# Altium schematic sheet 19_2_Coupled_PP0_Top.SchDoc(19_2_Coupled_PP0_Top)
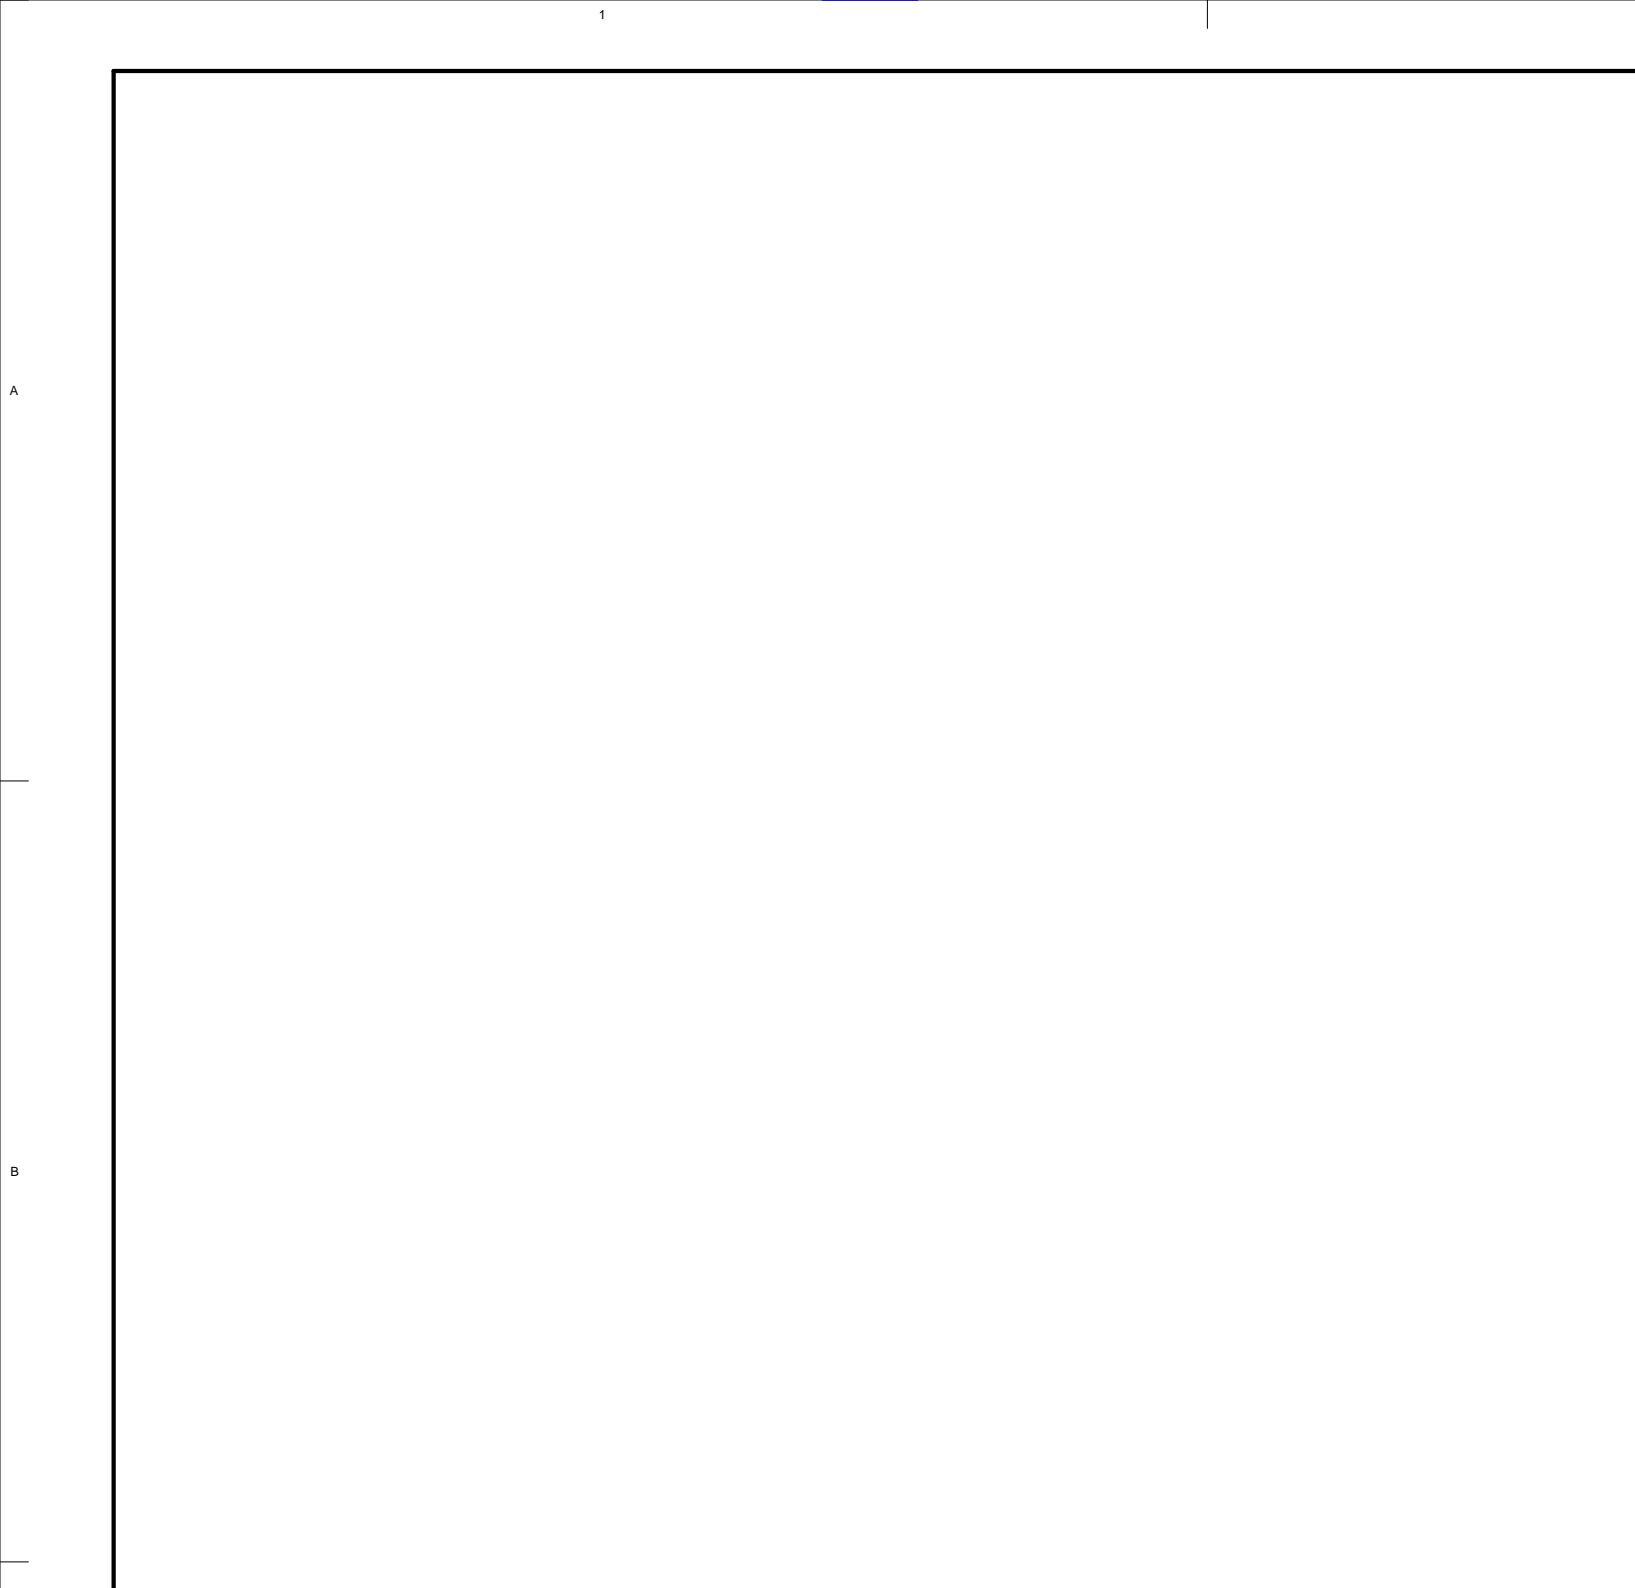
[diagram p0: 19_2_Coupled_PP0_Top.SchDoc, top left]
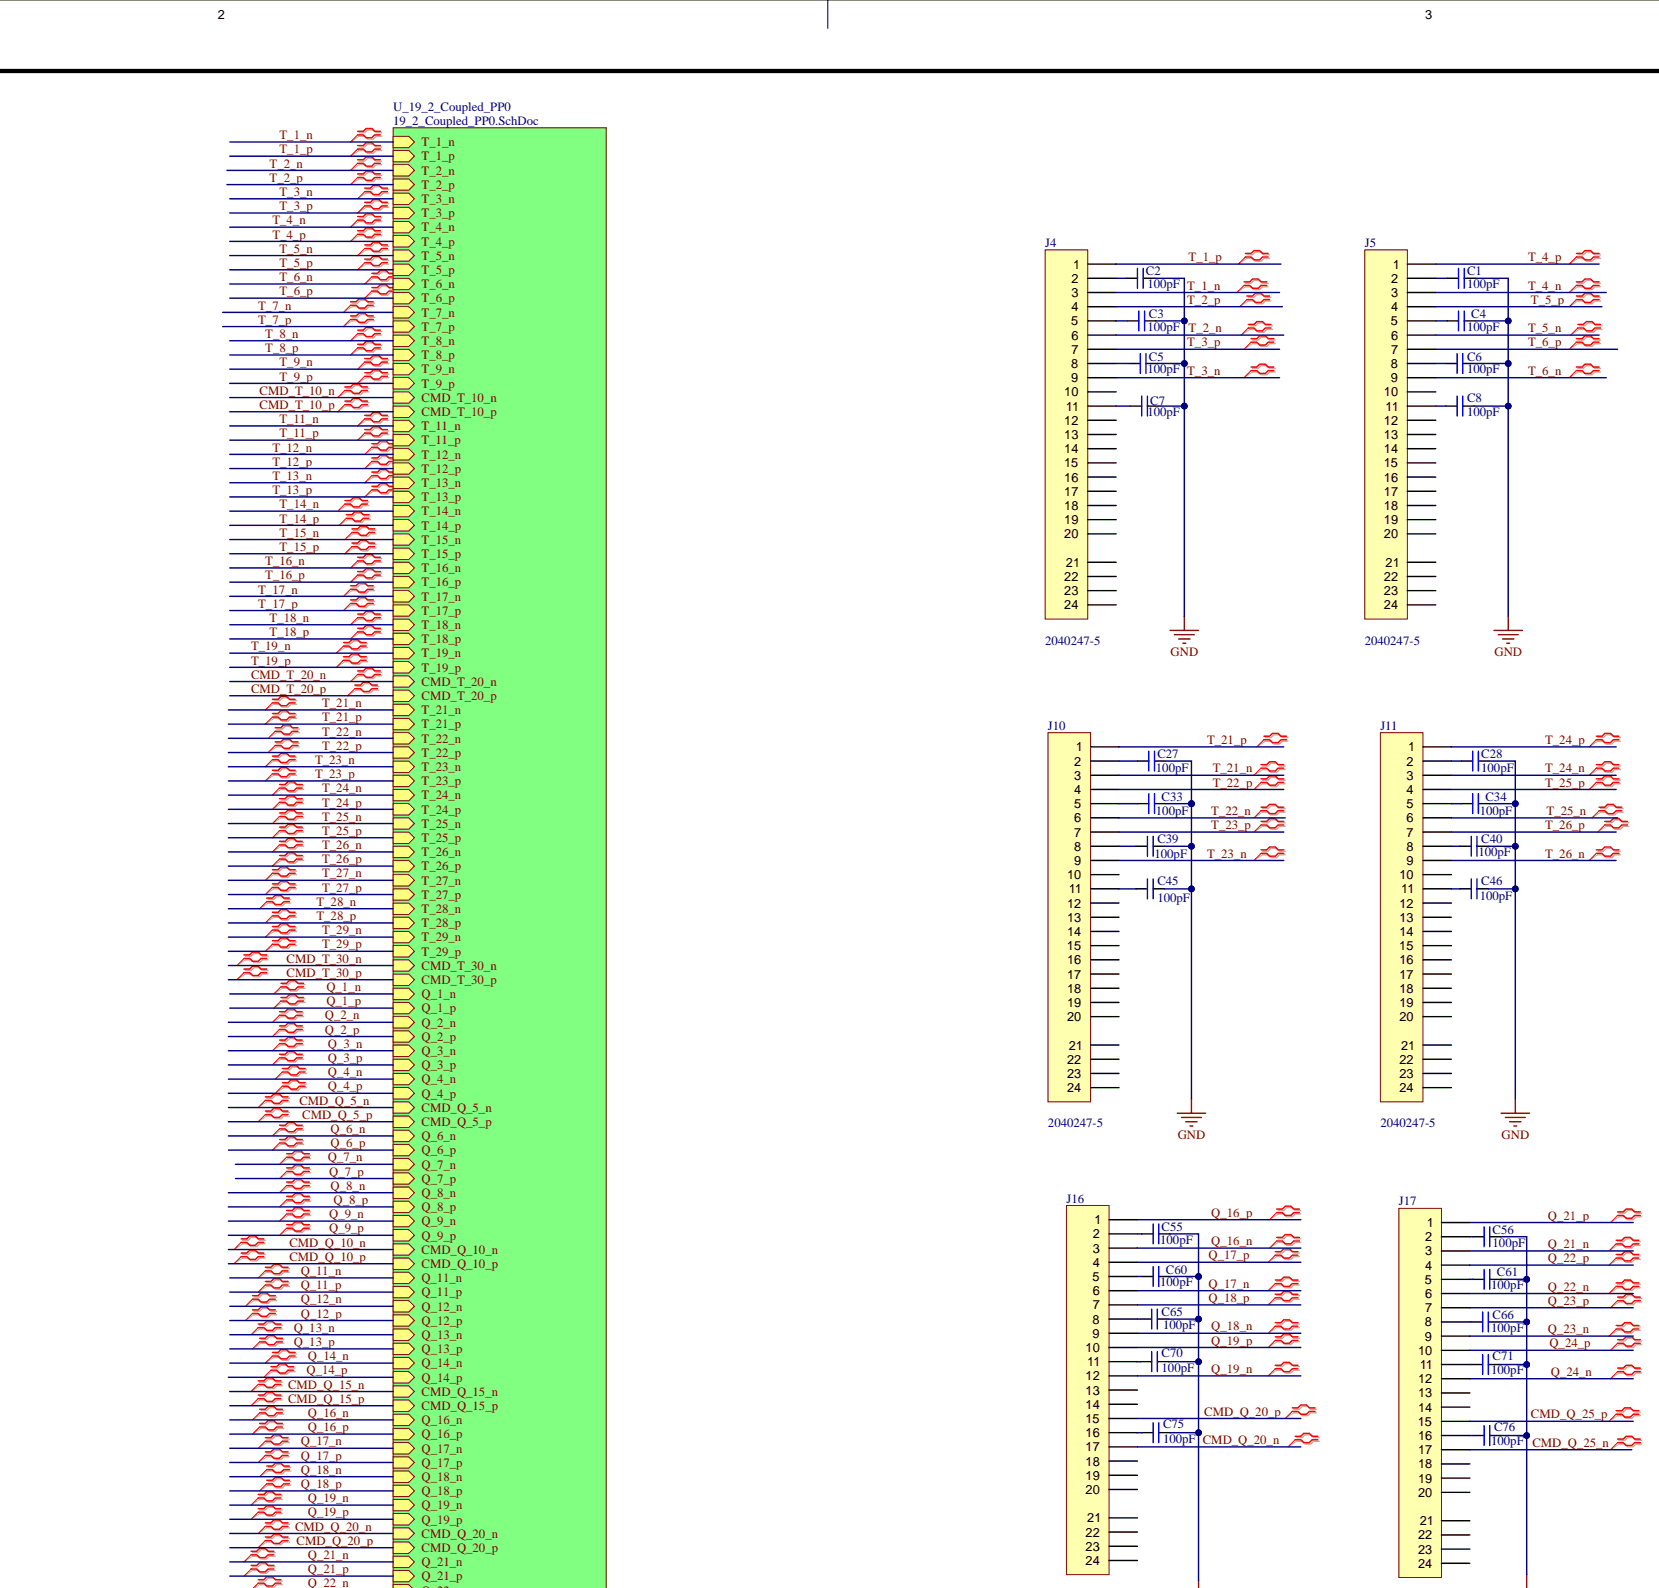
[diagram p1: 19_2_Coupled_PP0_Top.SchDoc, top centre]
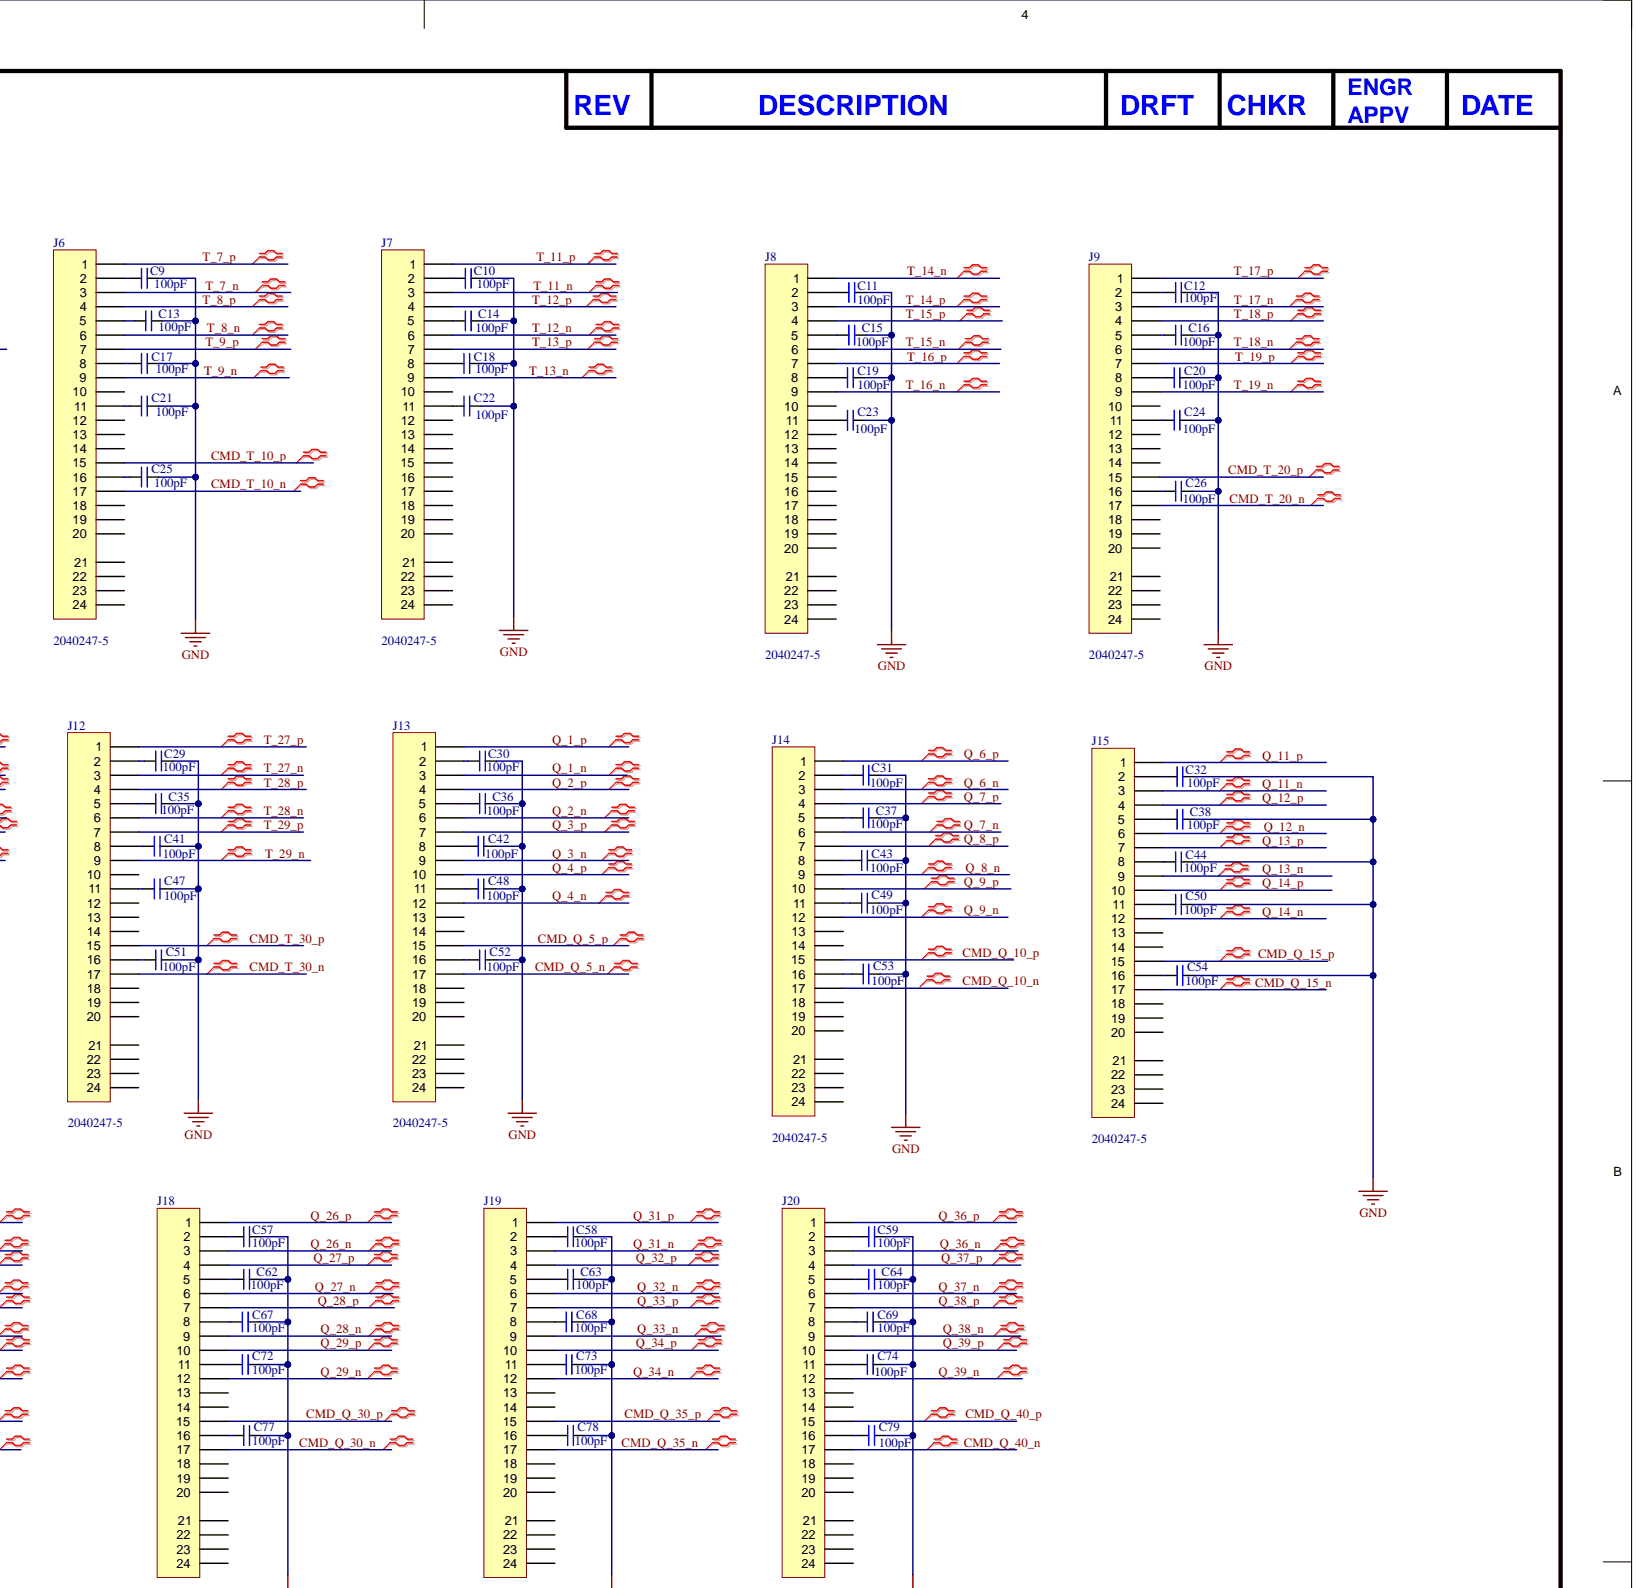
[diagram p2: 19_2_Coupled_PP0_Top.SchDoc, top right]
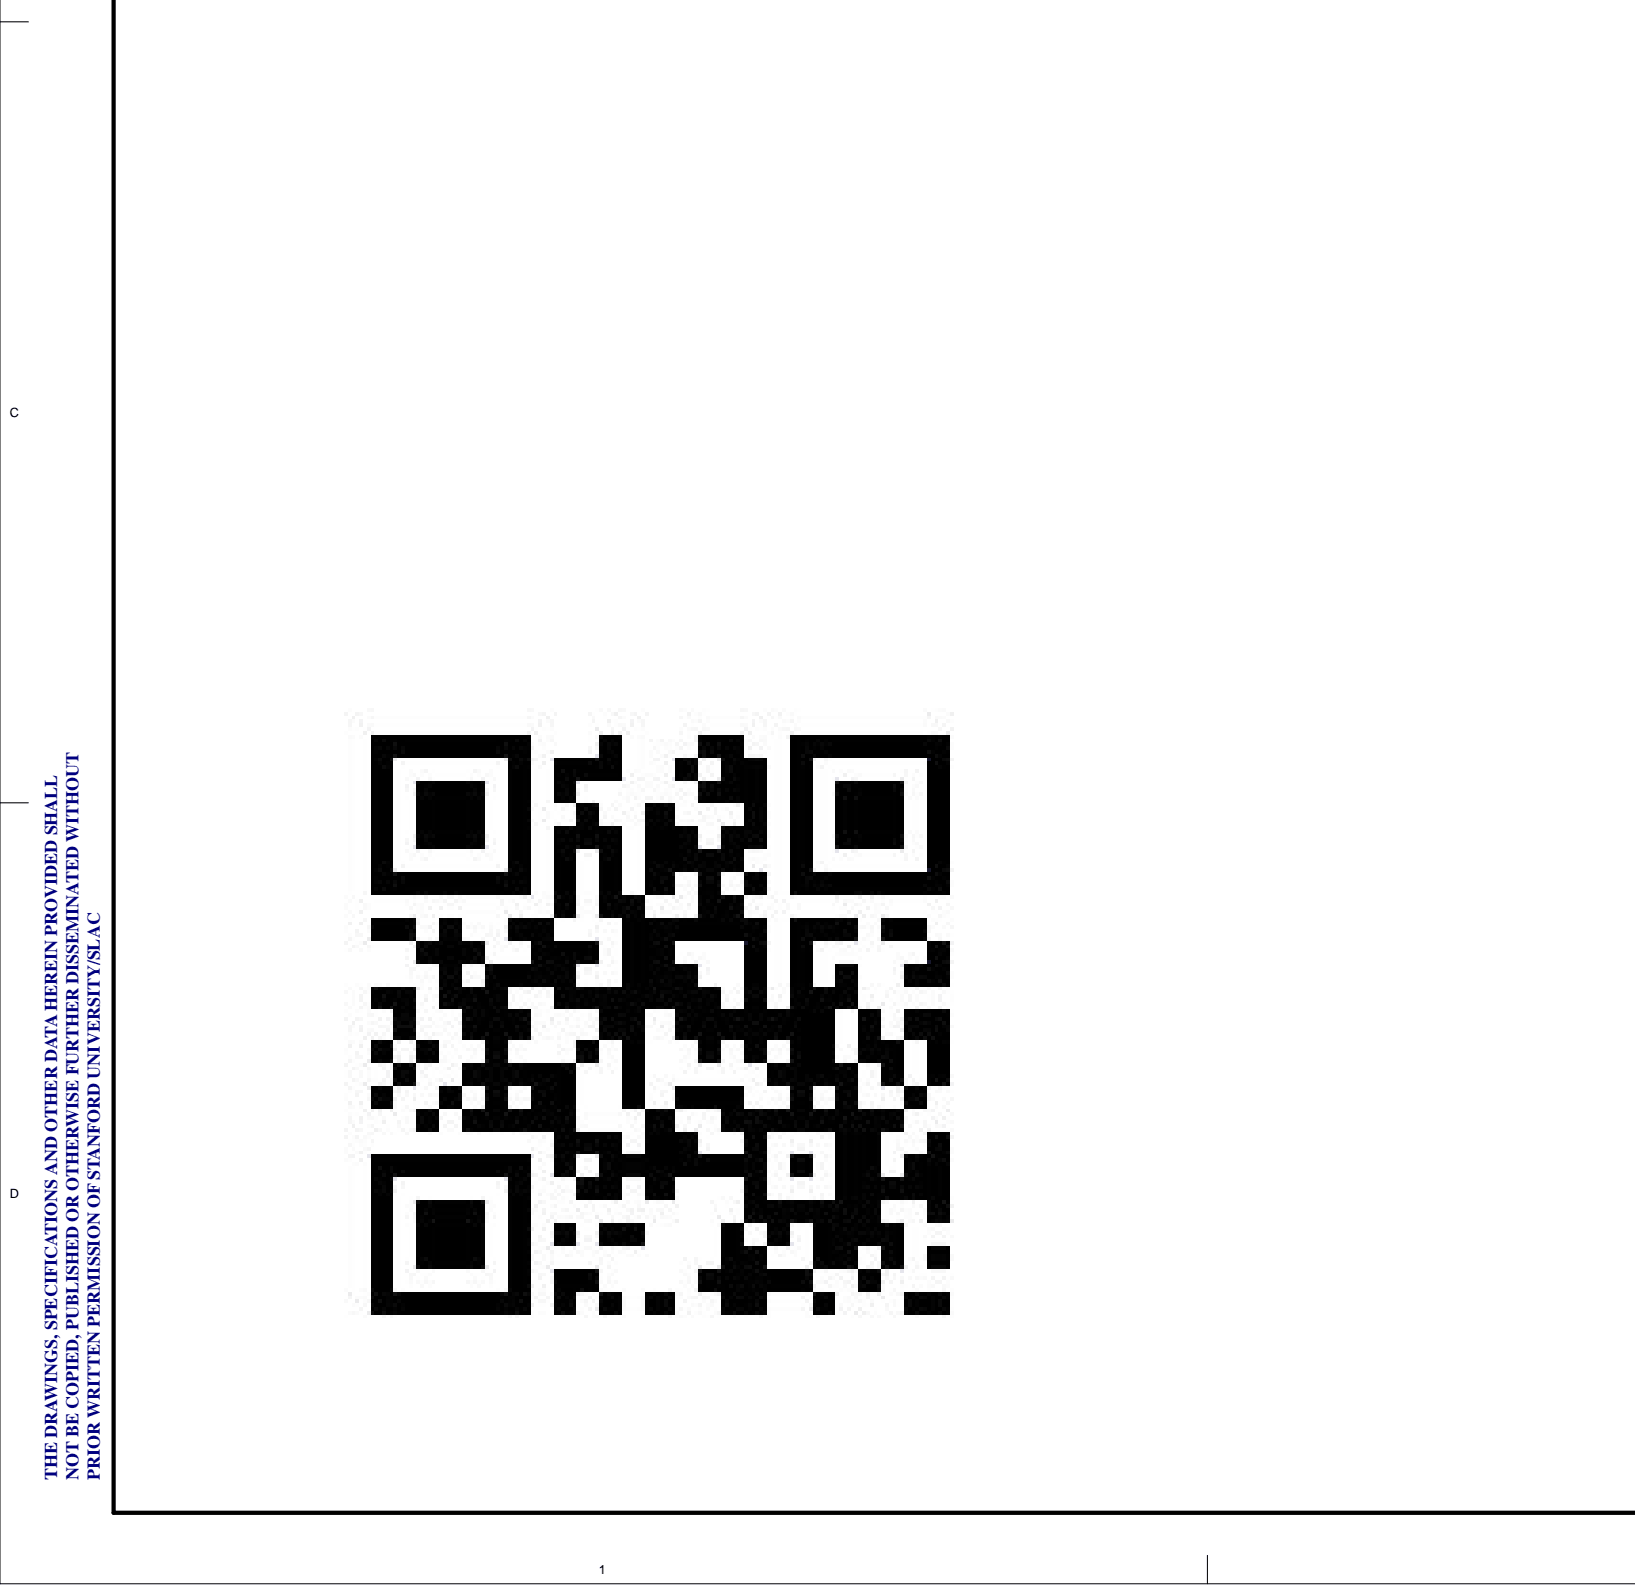
[diagram p3: 19_2_Coupled_PP0_Top.SchDoc, bottom left]
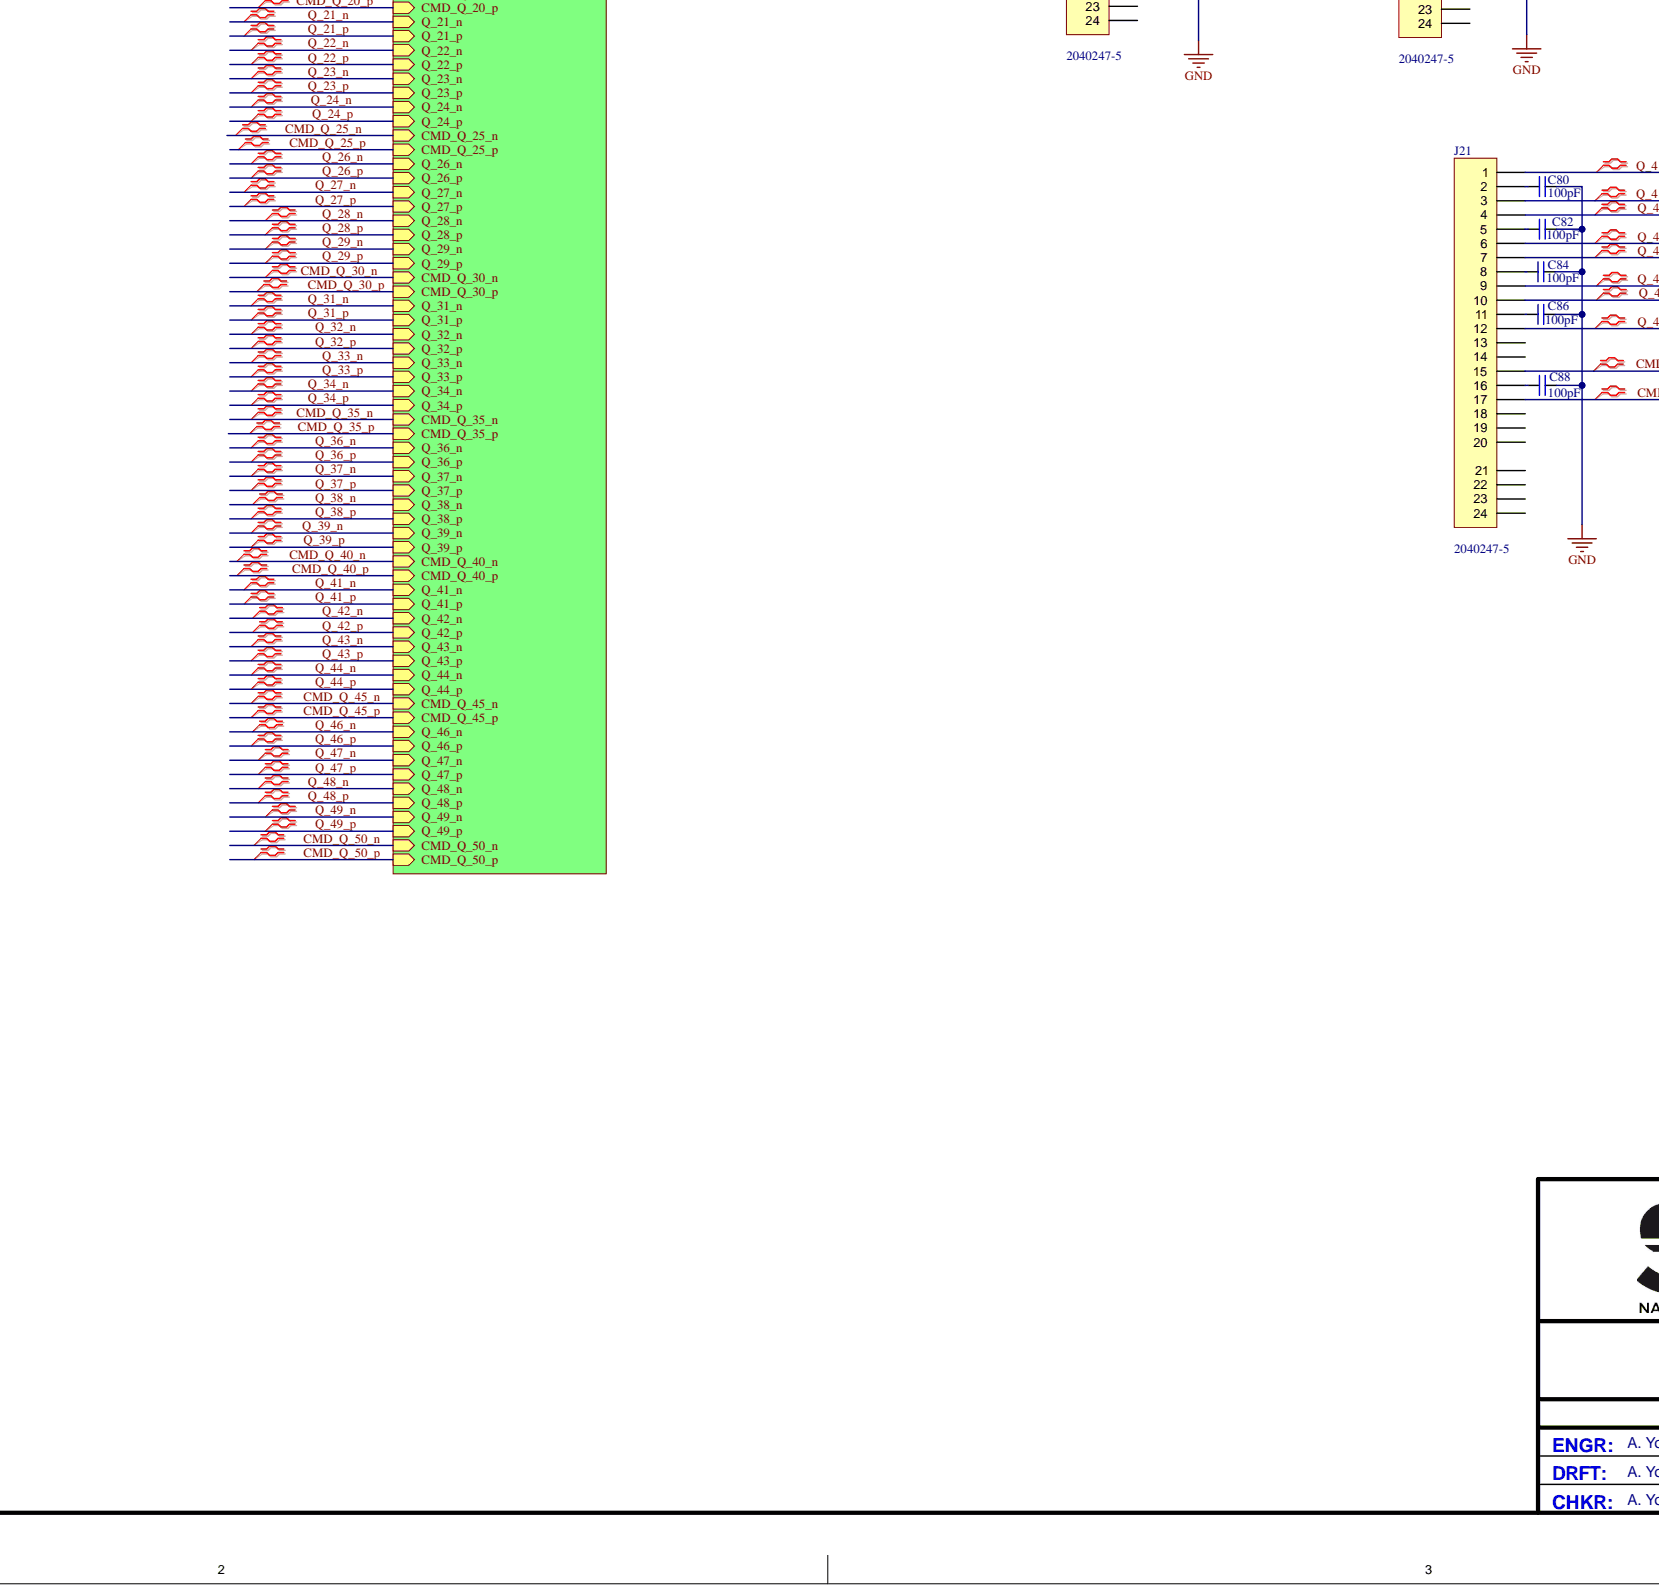
[diagram p4: 19_2_Coupled_PP0_Top.SchDoc, bottom centre]
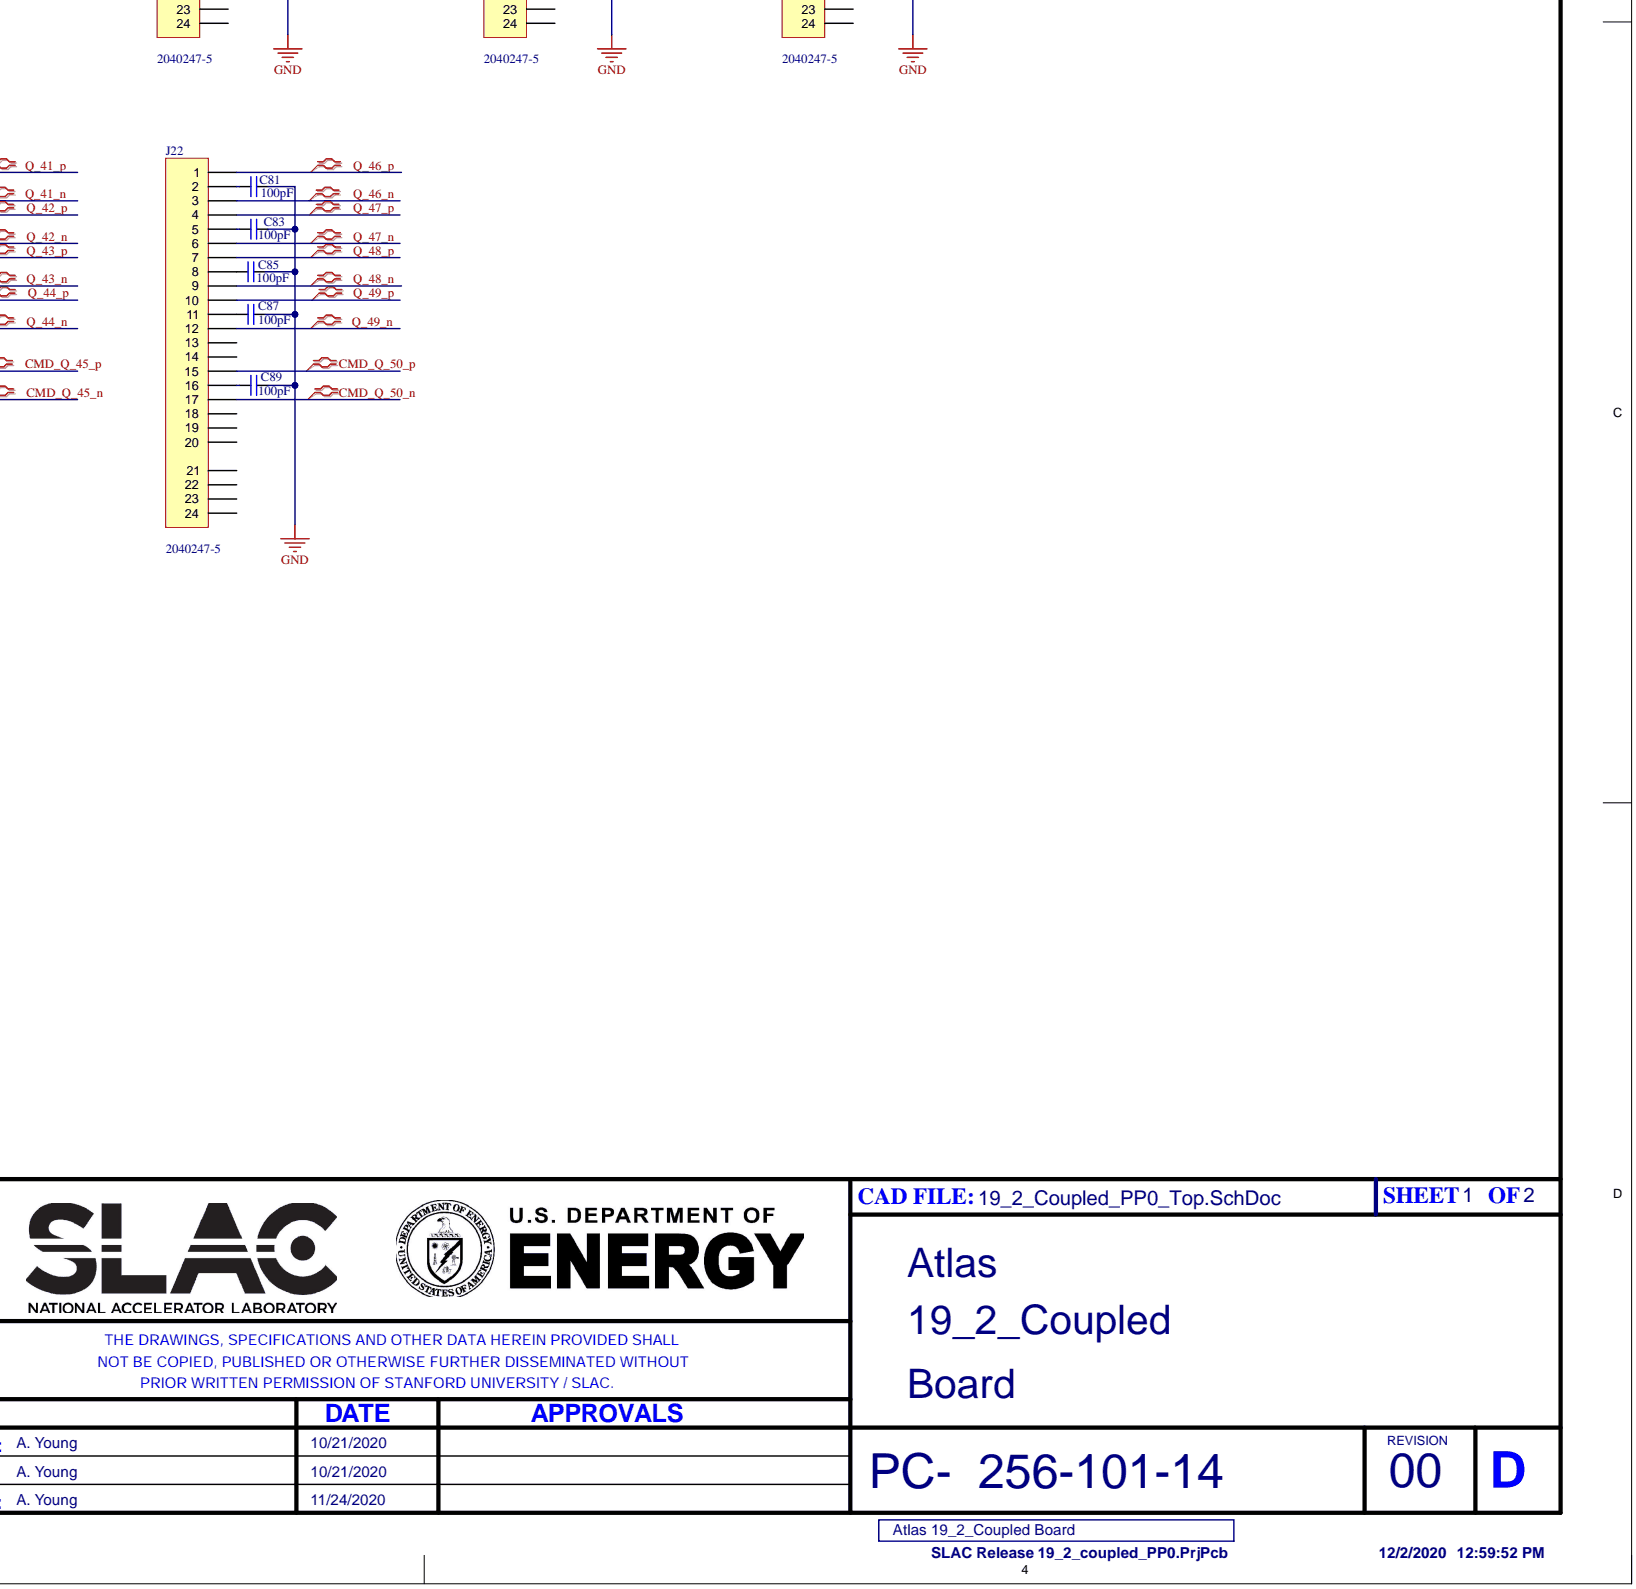
[diagram p5: 19_2_Coupled_PP0_Top.SchDoc, bottom right]

## Parts
C1  100pF  [SM0201]
C2  100pF  [SM0201]
C3  100pF  [SM0201]
C4  100pF  [SM0201]
C5  100pF  [SM0201]
C6  100pF  [SM0201]
C7  100pF  [SM0201]
C8  100pF  [SM0201]
C9  100pF  [SM0201]
C10  100pF  [SM0201]
C11  100pF  [SM0201]
C12  100pF  [SM0201]
C13  100pF  [SM0201]
C14  100pF  [SM0201]
C15  100pF  [SM0201]
C16  100pF  [SM0201]
C17  100pF  [SM0201]
C18  100pF  [SM0201]
C19  100pF  [SM0201]
C20  100pF  [SM0201]
C21  100pF  [SM0201]
C22  100pF  [SM0201]
C23  100pF  [SM0201]
C24  100pF  [SM0201]
C25  100pF  [SM0201]
C26  100pF  [SM0201]
C27  100pF  [SM0201]
C28  100pF  [SM0201]
C29  100pF  [SM0201]
C30  100pF  [SM0201]
C31  100pF  [SM0201]
C32  100pF  [SM0201]
C33  100pF  [SM0201]
C34  100pF  [SM0201]
C35  100pF  [SM0201]
C36  100pF  [SM0201]
C37  100pF  [SM0201]
C38  100pF  [SM0201]
C39  100pF  [SM0201]
C40  100pF  [SM0201]
C41  100pF  [SM0201]
C42  100pF  [SM0201]
C43  100pF  [SM0201]
C44  100pF  [SM0201]
C45  100pF  [SM0201]
C46  100pF  [SM0201]
C47  100pF  [SM0201]
C48  100pF  [SM0201]
C49  100pF  [SM0201]
C50  100pF  [SM0201]
C51  100pF  [SM0201]
C52  100pF  [SM0201]
C53  100pF  [SM0201]
C54  100pF  [SM0201]
C55  100pF  [SM0201]
C56  100pF  [SM0201]
C57  100pF  [SM0201]
C58  100pF  [SM0201]
C59  100pF  [SM0201]
C60  100pF  [SM0201]
C61  100pF  [SM0201]
C62  100pF  [SM0201]
C63  100pF  [SM0201]
C64  100pF  [SM0201]
C65  100pF  [SM0201]
C66  100pF  [SM0201]
C67  100pF  [SM0201]
C68  100pF  [SM0201]
C69  100pF  [SM0201]
C70  100pF  [SM0201]
C71  100pF  [SM0201]
C72  100pF  [SM0201]
C73  100pF  [SM0201]
C74  100pF  [SM0201]
C75  100pF  [SM0201]
C76  100pF  [SM0201]
C77  100pF  [SM0201]
C78  100pF  [SM0201]
C79  100pF  [SM0201]
C80  100pF  [SM0201]
C81  100pF  [SM0201]
C82  100pF  [SM0201]
C83  100pF  [SM0201]
C84  100pF  [SM0201]
C85  100pF  [SM0201]
C86  100pF  [SM0201]
C87  100pF  [SM0201]
C88  100pF  [SM0201]
C89  100pF  [SM0201]
J4  2040247-5  [Tyco_2040247-5]
J5  2040247-5  [Tyco_2040247-5]
J6  2040247-5  [Tyco_2040247-5]
J7  2040247-5  [Tyco_2040247-5]
J8  2040247-5  [Tyco_2040247-5]
J9  2040247-5  [Tyco_2040247-5]
J10  2040247-5  [Tyco_2040247-5]
J11  2040247-5  [Tyco_2040247-5]
J12  2040247-5  [Tyco_2040247-5]
J13  2040247-5  [Tyco_2040247-5]
J14  2040247-5  [Tyco_2040247-5]
J15  2040247-5  [Tyco_2040247-5]
J16  2040247-5  [Tyco_2040247-5]
J17  2040247-5  [Tyco_2040247-5]
J18  2040247-5  [Tyco_2040247-5]
J19  2040247-5  [Tyco_2040247-5]
J20  2040247-5  [Tyco_2040247-5]
J21  2040247-5  [Tyco_2040247-5]
J22  2040247-5  [Tyco_2040247-5]

## Nets
GND: C1.1 C2.1 C3.1 C4.1 C5.1 C6.1 C7.1 C8.1 C9.1 C10.1 C11.1 C12.1 C13.1 C14.1 C15.1 C16.1 C17.1 C18.1 C19.1 C20.1 C21.1 C22.1 C23.1 C24.1 C25.1 C26.1 C27.1 C28.1 C29.1 C30.1 C31.1 C32.1 C33.1 C34.1 C35.1 C36.1 C37.1 C38.1 C39.1 C40.1 C41.1 C42.1 C43.1 C44.1 C45.1 C46.1 C47.1 C48.1 C49.1 C50.1 C51.1 C52.1 C53.1 C54.1 C55.1 C56.1 C57.1 C58.1 C59.1 C60.1 C61.1 C62.1 C63.1 C64.1 C65.1 C66.1 C67.1 C68.1 C69.1 C70.1 C71.1 C72.1 C73.1 C74.1 C75.1 C76.1 C77.1 C78.1 C79.1 C80.1 C81.1 C82.1 C83.1 C84.1 C85.1 C86.1 C87.1 C88.1 C89.1
CMD_Q_5_n: J13.17
CMD_Q_5_p: J13.15
CMD_Q_10_n: J14.17
CMD_Q_10_p: J14.15
CMD_Q_15_n: J15.17
CMD_Q_15_p: J15.15
CMD_Q_20_n: J16.17
CMD_Q_20_p: J16.15
CMD_Q_25_n: J17.17
CMD_Q_25_p: J17.15
CMD_Q_30_n: J18.17
CMD_Q_30_p: J18.15
CMD_Q_35_n: J19.17
CMD_Q_35_p: J19.15
CMD_Q_40_n: J20.17
CMD_Q_40_p: J20.15
CMD_Q_45_n: J21.17
CMD_Q_45_p: J21.15
CMD_Q_50_n: J22.17
CMD_Q_50_p: J22.15
CMD_T_10_n: J6.17
CMD_T_10_p: J6.15
CMD_T_20_n: J9.17
CMD_T_20_p: J9.15
CMD_T_30_n: J12.17
CMD_T_30_p: J12.15
Q_1_n: J13.3
Q_1_p: J13.1
Q_2_n: J13.6
Q_2_p: J13.4
Q_3_n: J13.9
Q_3_p: J13.7
Q_4_n: J13.12
Q_4_p: J13.10
Q_6_n: J14.3
Q_6_p: J14.1
Q_7_n: J14.6
Q_7_p: J14.4
Q_8_n: J14.9
Q_8_p: J14.7
Q_9_n: J14.12
Q_9_p: J14.10
Q_11_n: J15.3
Q_11_p: J15.1
Q_12_n: J15.6
Q_12_p: J15.4
Q_13_n: J15.9
Q_13_p: J15.7
Q_14_n: J15.12
Q_14_p: J15.10
Q_16_n: J16.3
Q_16_p: J16.1
Q_17_n: J16.6
Q_17_p: J16.4
Q_18_n: J16.9
Q_18_p: J16.7
Q_19_n: J16.12
Q_19_p: J16.10
Q_21_n: J17.3
Q_21_p: J17.1
Q_22_n: J17.6
Q_22_p: J17.4
Q_23_n: J17.9
Q_23_p: J17.7
Q_24_n: J17.12
Q_24_p: J17.10
Q_26_n: J18.3
Q_26_p: J18.1
Q_27_n: J18.6
Q_27_p: J18.4
Q_28_n: J18.9
Q_28_p: J18.7
Q_29_n: J18.12
Q_29_p: J18.10
Q_31_n: J19.3
Q_31_p: J19.1
Q_32_n: J19.6
Q_32_p: J19.4
Q_33_n: J19.9
Q_33_p: J19.7
Q_34_n: J19.12
Q_34_p: J19.10
Q_36_n: J20.3
Q_36_p: J20.1
Q_37_n: J20.6
Q_37_p: J20.4
Q_38_n: J20.9
Q_38_p: J20.7
Q_39_n: J20.12
Q_39_p: J20.10
Q_41_n: J21.3
Q_41_p: J21.1
Q_42_n: J21.6
Q_42_p: J21.4
Q_43_n: J21.9
Q_43_p: J21.7
Q_44_n: J21.12
Q_44_p: J21.10
Q_46_n: J22.3
Q_46_p: J22.1
Q_47_n: J22.6
Q_47_p: J22.4
Q_48_n: J22.9
Q_48_p: J22.7
Q_49_n: J22.12
Q_49_p: J22.10
T_1_n: J4.3
T_1_p: J4.1
T_2_n: J4.6
T_2_p: J4.4
T_3_n: J4.9
T_3_p: J4.7
T_4_n: J5.3
T_4_p: J5.1
T_5_n: J5.6
T_5_p: J5.4
T_6_n: J5.9
T_6_p: J5.7
T_7_n: J6.3
T_7_p: J6.1
T_8_n: J6.6
T_8_p: J6.4
T_9_n: J6.9
T_9_p: J6.7
T_11_n: J7.3
T_11_p: J7.1
T_12_n: J7.6
T_12_p: J7.4
T_13_n: J7.9
T_13_p: J7.7
T_14_n: J8.1
T_14_p: J8.3
T_15_n: J8.6
T_15_p: J8.4
T_16_n: J8.9
T_16_p: J8.7
T_17_n: J9.3
T_17_p: J9.1
T_18_n: J9.6
T_18_p: J9.4
T_19_n: J9.9
T_19_p: J9.7
T_21_n: J10.3
T_21_p: J10.1
T_22_n: J10.6
T_22_p: J10.4
T_23_n: J10.9
T_23_p: J10.7
T_24_n: J11.3
T_24_p: J11.1
T_25_n: J11.6
T_25_p: J11.4
T_26_n: J11.9
T_26_p: J11.7
T_27_n: J12.3
T_27_p: J12.1
T_28_n: J12.6
T_28_p: J12.4
T_29_n: J12.9
T_29_p: J12.7
NetC1_2: C1.2 J5.2
NetC2_2: C2.2 J4.2
NetC3_2: C3.2 J4.5
NetC4_2: C4.2 J5.5
NetC5_2: C5.2 J4.8
NetC6_2: C6.2 J5.8
NetC7_2: C7.2 J4.11
NetC8_2: C8.2 J5.11
NetC9_2: C9.2 J6.2
NetC10_2: C10.2 J7.2
NetC11_2: C11.2 J8.2
NetC12_2: C12.2 J9.2
NetC13_2: C13.2 J6.5
NetC14_2: C14.2 J7.5
NetC15_2: C15.2 J8.5
NetC16_2: C16.2 J9.5
NetC17_2: C17.2 J6.8
NetC18_2: C18.2 J7.8
NetC19_2: C19.2 J8.8
NetC20_2: C20.2 J9.8
NetC21_2: C21.2 J6.11
NetC22_2: C22.2 J7.11
NetC23_2: C23.2 J8.11
NetC24_2: C24.2 J9.11
NetC25_2: C25.2 J6.16
NetC26_2: C26.2 J9.16
NetC27_2: C27.2 J10.2
NetC28_2: C28.2 J11.2
NetC29_2: C29.2 J12.2
NetC30_2: C30.2 J13.2
NetC31_2: C31.2 J14.2
NetC32_2: C32.2 J15.2
NetC33_2: C33.2 J10.5
NetC34_2: C34.2 J11.5
NetC35_2: C35.2 J12.5
NetC36_2: C36.2 J13.5
NetC37_2: C37.2 J14.5
NetC38_2: C38.2 J15.5
NetC39_2: C39.2 J10.8
NetC40_2: C40.2 J11.8
NetC41_2: C41.2 J12.8
NetC42_2: C42.2 J13.8
NetC43_2: C43.2 J14.8
NetC44_2: C44.2 J15.8
NetC45_2: C45.2 J10.11
NetC46_2: C46.2 J11.11
NetC47_2: C47.2 J12.11
NetC48_2: C48.2 J13.11
NetC49_2: C49.2 J14.11
NetC50_2: C50.2 J15.11
NetC51_2: C51.2 J12.16
NetC52_2: C52.2 J13.16
NetC53_2: C53.2 J14.16
NetC54_2: C54.2 J15.16
NetC55_2: C55.2 J16.2
NetC56_2: C56.2 J17.2
NetC57_2: C57.2 J18.2
NetC58_2: C58.2 J19.2
NetC59_2: C59.2 J20.2
NetC60_2: C60.2 J16.5
NetC61_2: C61.2 J17.5
NetC62_2: C62.2 J18.5
NetC63_2: C63.2 J19.5
NetC64_2: C64.2 J20.5
NetC65_2: C65.2 J16.8
NetC66_2: C66.2 J17.8
NetC67_2: C67.2 J18.8
NetC68_2: C68.2 J19.8
NetC69_2: C69.2 J20.8
NetC70_2: C70.2 J16.11
NetC71_2: C71.2 J17.11
NetC72_2: C72.2 J18.11
NetC73_2: C73.2 J19.11
NetC74_2: C74.2 J20.11
NetC75_2: C75.2 J16.16
NetC76_2: C76.2 J17.16
NetC77_2: C77.2 J18.16
NetC78_2: C78.2 J19.16
NetC79_2: C79.2 J20.16
NetC80_2: C80.2 J21.2
NetC81_2: C81.2 J22.2
NetC82_2: C82.2 J21.5
NetC83_2: C83.2 J22.5
NetC84_2: C84.2 J21.8
NetC85_2: C85.2 J22.8
NetC86_2: C86.2 J21.11
NetC87_2: C87.2 J22.11
NetC88_2: C88.2 J21.16
NetC89_2: C89.2 J22.16
NetJ4_10: J4.10
NetJ4_12: J4.12
NetJ4_13: J4.13
NetJ4_14: J4.14
NetJ4_15: J4.15
NetJ4_16: J4.16
NetJ4_17: J4.17
NetJ4_18: J4.18
NetJ4_19: J4.19
NetJ4_20: J4.20
NetJ4_21: J4.21
NetJ4_22: J4.22
NetJ4_23: J4.23
NetJ4_24: J4.24
NetJ5_10: J5.10
NetJ5_12: J5.12
NetJ5_13: J5.13
NetJ5_14: J5.14
NetJ5_15: J5.15
NetJ5_16: J5.16
NetJ5_17: J5.17
NetJ5_18: J5.18
NetJ5_19: J5.19
NetJ5_20: J5.20
NetJ5_21: J5.21
NetJ5_22: J5.22
NetJ5_23: J5.23
NetJ5_24: J5.24
NetJ6_10: J6.10
NetJ6_12: J6.12
NetJ6_13: J6.13
NetJ6_14: J6.14
NetJ6_18: J6.18
NetJ6_19: J6.19
NetJ6_20: J6.20
NetJ6_21: J6.21
NetJ6_22: J6.22
NetJ6_23: J6.23
NetJ6_24: J6.24
NetJ7_10: J7.10
NetJ7_12: J7.12
NetJ7_13: J7.13
NetJ7_14: J7.14
NetJ7_15: J7.15
NetJ7_16: J7.16
NetJ7_17: J7.17
NetJ7_18: J7.18
NetJ7_19: J7.19
NetJ7_20: J7.20
NetJ7_21: J7.21
NetJ7_22: J7.22
NetJ7_23: J7.23
NetJ7_24: J7.24
NetJ8_10: J8.10
NetJ8_12: J8.12
NetJ8_13: J8.13
NetJ8_14: J8.14
NetJ8_15: J8.15
NetJ8_16: J8.16
NetJ8_17: J8.17
NetJ8_18: J8.18
NetJ8_19: J8.19
NetJ8_20: J8.20
NetJ8_21: J8.21
NetJ8_22: J8.22
NetJ8_23: J8.23
NetJ8_24: J8.24
NetJ9_10: J9.10
NetJ9_12: J9.12
NetJ9_13: J9.13
NetJ9_14: J9.14
NetJ9_18: J9.18
NetJ9_19: J9.19
NetJ9_20: J9.20
NetJ9_21: J9.21
NetJ9_22: J9.22
NetJ9_23: J9.23
NetJ9_24: J9.24
NetJ10_10: J10.10
NetJ10_12: J10.12
NetJ10_13: J10.13
NetJ10_14: J10.14
NetJ10_15: J10.15
NetJ10_16: J10.16
NetJ10_17: J10.17
NetJ10_18: J10.18
NetJ10_19: J10.19
NetJ10_20: J10.20
NetJ10_21: J10.21
NetJ10_22: J10.22
NetJ10_23: J10.23
NetJ10_24: J10.24
NetJ11_10: J11.10
NetJ11_12: J11.12
NetJ11_13: J11.13
NetJ11_14: J11.14
NetJ11_15: J11.15
NetJ11_16: J11.16
NetJ11_17: J11.17
NetJ11_18: J11.18
NetJ11_19: J11.19
NetJ11_20: J11.20
NetJ11_21: J11.21
NetJ11_22: J11.22
NetJ11_23: J11.23
NetJ11_24: J11.24
NetJ12_10: J12.10
NetJ12_12: J12.12
NetJ12_13: J12.13
NetJ12_14: J12.14
NetJ12_18: J12.18
NetJ12_19: J12.19
NetJ12_20: J12.20
NetJ12_21: J12.21
NetJ12_22: J12.22
NetJ12_23: J12.23
NetJ12_24: J12.24
NetJ13_13: J13.13
NetJ13_14: J13.14
NetJ13_18: J13.18
NetJ13_19: J13.19
NetJ13_20: J13.20
NetJ13_21: J13.21
NetJ13_22: J13.22
NetJ13_23: J13.23
NetJ13_24: J13.24
NetJ14_13: J14.13
NetJ14_14: J14.14
NetJ14_18: J14.18
NetJ14_19: J14.19
NetJ14_20: J14.20
NetJ14_21: J14.21
NetJ14_22: J14.22
NetJ14_23: J14.23
NetJ14_24: J14.24
NetJ15_13: J15.13
NetJ15_14: J15.14
NetJ15_18: J15.18
NetJ15_19: J15.19
NetJ15_20: J15.20
NetJ15_21: J15.21
NetJ15_22: J15.22
NetJ15_23: J15.23
NetJ15_24: J15.24
NetJ16_13: J16.13
NetJ16_14: J16.14
NetJ16_18: J16.18
NetJ16_19: J16.19
NetJ16_20: J16.20
NetJ16_21: J16.21
NetJ16_22: J16.22
NetJ16_23: J16.23
NetJ16_24: J16.24
NetJ17_13: J17.13
NetJ17_14: J17.14
NetJ17_18: J17.18
NetJ17_19: J17.19
NetJ17_20: J17.20
NetJ17_21: J17.21
NetJ17_22: J17.22
NetJ17_23: J17.23
NetJ17_24: J17.24
NetJ18_13: J18.13
NetJ18_14: J18.14
NetJ18_18: J18.18
NetJ18_19: J18.19
NetJ18_20: J18.20
NetJ18_21: J18.21
NetJ18_22: J18.22
NetJ18_23: J18.23
NetJ18_24: J18.24
NetJ19_13: J19.13
NetJ19_14: J19.14
NetJ19_18: J19.18
NetJ19_19: J19.19
NetJ19_20: J19.20
NetJ19_21: J19.21
NetJ19_22: J19.22
NetJ19_23: J19.23
NetJ19_24: J19.24
NetJ20_13: J20.13
NetJ20_14: J20.14
NetJ20_18: J20.18
NetJ20_19: J20.19
NetJ20_20: J20.20
NetJ20_21: J20.21
NetJ20_22: J20.22
NetJ20_23: J20.23
NetJ20_24: J20.24
NetJ21_13: J21.13
NetJ21_14: J21.14
NetJ21_18: J21.18
NetJ21_19: J21.19
NetJ21_20: J21.20
NetJ21_21: J21.21
NetJ21_22: J21.22
NetJ21_23: J21.23
NetJ21_24: J21.24
NetJ22_13: J22.13
NetJ22_14: J22.14
NetJ22_18: J22.18
NetJ22_19: J22.19
NetJ22_20: J22.20
NetJ22_21: J22.21
NetJ22_22: J22.22
NetJ22_23: J22.23
NetJ22_24: J22.24

## Library data of the parts
C1-C89 = C0201N101JW500: PPI, 0201N101JW500; CAP. 100pF 50V 5%, SM0201; Unit_Price_Static: 2.00; Voltage: 500V; Component Kind: Standard; ComponentLink1Description: Datasheet; Manufacturer: PPI; Manufacturer Part Number: 0201N101JW500; Package / Case: SM0201; PartNumber: 0201N101JW500; Simulation: Capacitor; Tolerance: 5%; Value: 100pF; ComponentLink1URL: V:\CD\Altium\Datasheets\Capacitors\Kemet\Ceramic Chip Capacitor.pdf; Library Name: yee_sch_lib.SchLib
J4-J22 = Tyco_2040247-5: Tyco; 2040247-5, Display Port Right Angle SMT, Tyco_2040247-5; Unit_Price_Static: 1.00; Component Kind: Standard; ComponentLink: http://cnctech.us/pdfs/3220-XX-0300-00.pdf; Manufacturer: TYCO; Manufacturer Part Number: 2040247-5; Package / Case: Tyco_2040247-5; Library Name: yee_sch_lib.SchLib
